# Altium schematic sheet DriverChip.SchDoc
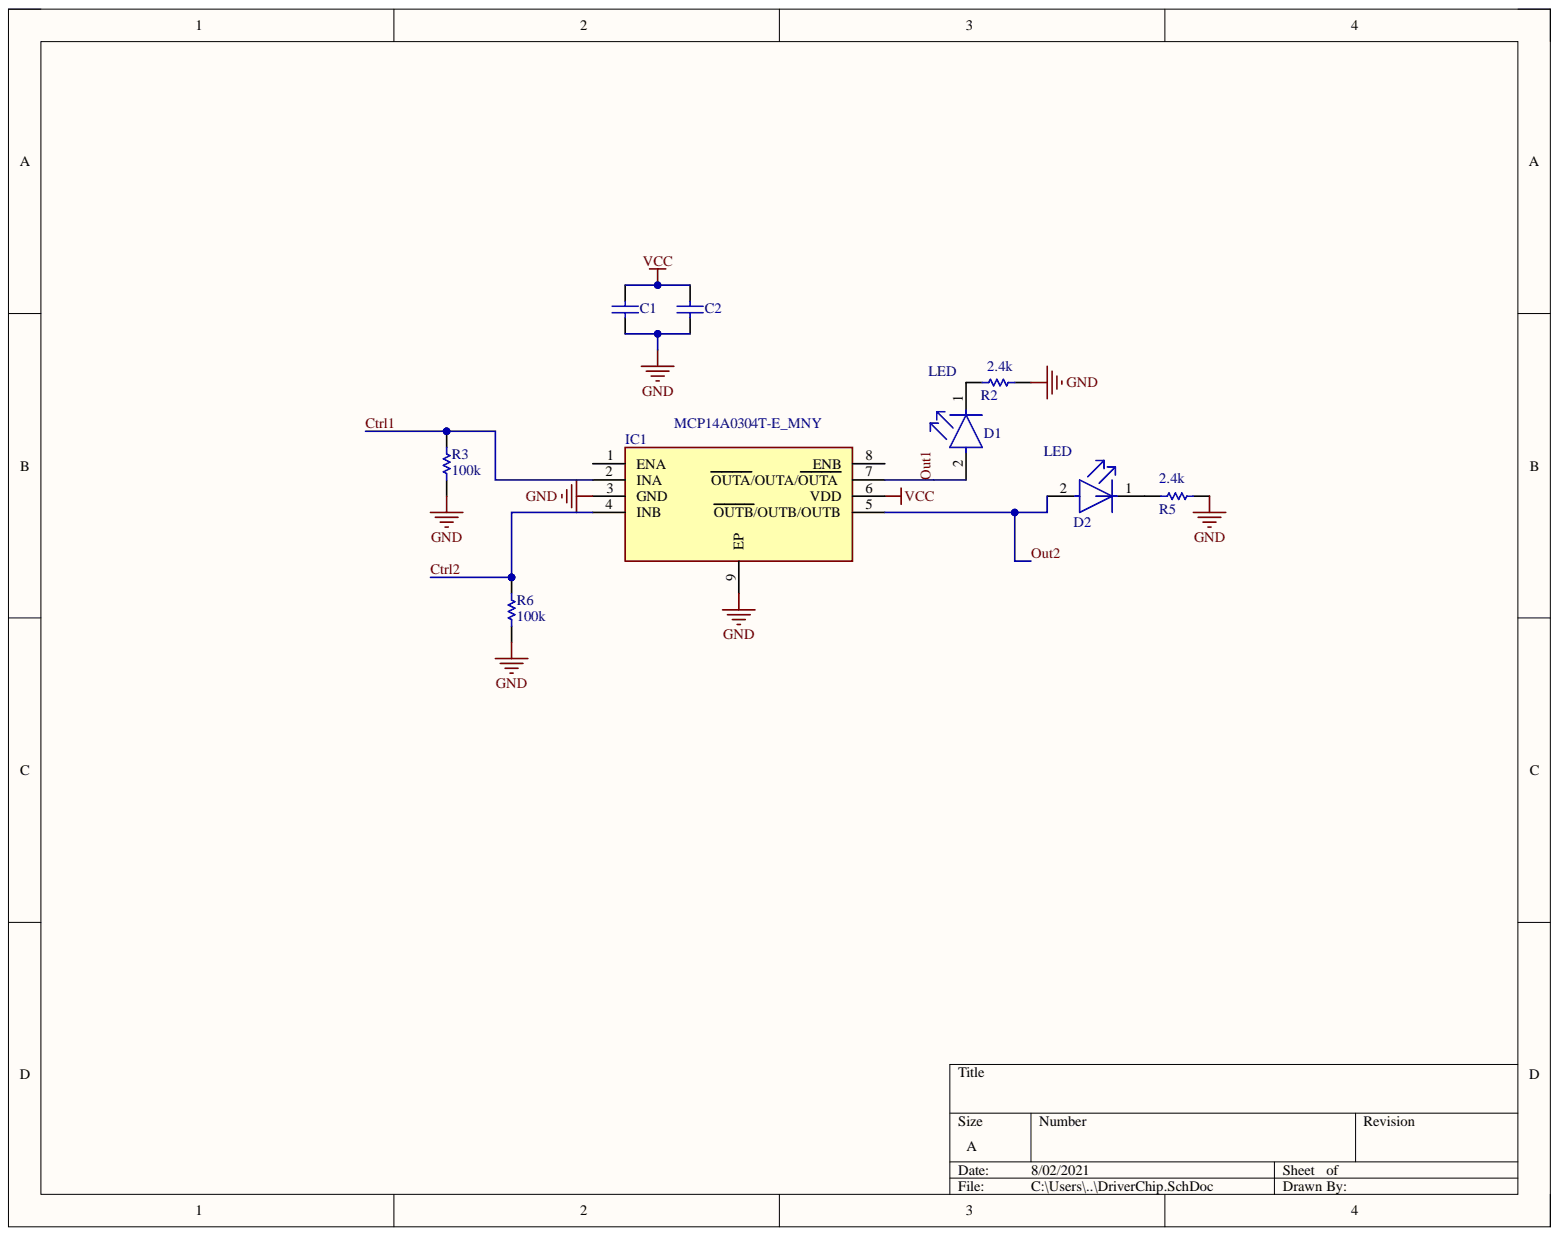
[diagram p0: DriverChip.SchDoc]

## Parts
C1  C0603C105K3PAC7867  [CAPC1608X90X35NL15T15]  (value not drawn)
C2  C0603C105K3PAC7867  [CAPC1608X90X35NL15T15]  (value not drawn)
D1  LED  [FP-LTST-C191TBKT-MFG]
D2  LED  [FP-LTST-C191TBKT-MFG]
IC1  MCP14A0304T-E_MNY  [SON50P300X200X80-9N-D]
R2  2.4k  [RESC1608X55X30ML20T20]
R3  100k  [RESC1608X55X30ML15T15]
R5  2.4k  [RESC1608X55X30ML20T20]
R6  100k  [RESC1608X55X30ML15T15]

## Nets
GND: C1.1 C2.1 IC1.3(GND) IC1.9(EP) R2.1 R3.2 R5.1 R6.2
VCC: C1.2 C2.2 IC1.6(VDD)
Ctrl1: IC1.2(INA) R3.1
Ctrl2: IC1.4(INB) R6.1
Out1: D1.2 IC1.7(OUTA/OUTA/OUTA)
Out2: D2.2 IC1.5(OUTB/OUTB/OUTB)
NetD1_1: D1.1 R2.2
NetD2_1: D2.1 R5.2

Not connected: IC1 1(ENA) 8(ENB)

## Library data of the parts
C1 C2 = CMP-2006-02216-2: Manufacturer: Kemet; Component Kind: Standard; Library Name: Altium Content Vault; Part Number: C0603C105K3PAC7867
D1 D2 = CMP-22017-000008-1: LED BLUE CLEAR CHIP SMD; Dominant Wavelength: 475nm; Forward Current: 20mA; Forward Voltage: 3.8V; Lens Color: Colorless; Lens Style: Diffused; Luminous Intensity: 180mcd; Max Power Dissipation: 75mW; Number of Elements: 1; Power Dissipation: 76mW; Reverse Voltage: 5V; Viewing Angle: 130°; Wavelength: 468nm; Case Code (Imperial): 0603; Case Code (Metric): 1608; Case/Package: 0603; Color: Blue; Component Kind: Standard; Depth: 1.6mm; Height: 0.55mm; Illumination Color: Blue; Lead Free: Lead Free; Length: 0.8mm; Lens Transparency: Clear; Library Name: Altium Content Vault; Max Operating Temperature: 80°C; Min Operating Temperature: -20°C; Mount: Surface Mount; Number of LEDs: 1; Number of Pins: 2; Package Quantity: 1; Packaging: Tape and Reel; Radiation Hardening: No; Test Current: 20mA; Width: 0.8mm
IC1 = MCP14A0304T-E_MNY: Integrated Circuit; Manufacturer_Name: Microchip; Manufacturer_Part_Number: MCP14A0304T-E/MNY; Component Kind: Standard; Datasheet Link: https://componentsearchengine.com/Datasheets/1/MCP14A0304T-E_MNY.pdf; Height: 0.8mm; Mouser Part Number: 579-MCP14A0304T-E/MN; Mouser Price/Stock: https://www.mouser.co.uk/ProductDetail/Microchip-Technology/MCP14A0304T-E-MNY?qs=w%2Fv1CP2dgqr6wcJcG4624Q%3D%3D
R2 R5 = CMP-2000-00965-1: Manufacturer: Panasonic; Part Number: ERA3AEB242V; Component Kind: Standard; Library Name: Altium Content Vault
R3 R6 = CMP-2000-00182-1: Manufacturer: Panasonic; Part Number: ERJ-3EKF1003V; Component Kind: Standard; Library Name: Altium Content Vault
